# Altium schematic sheet Sch_Lora.SchDoc
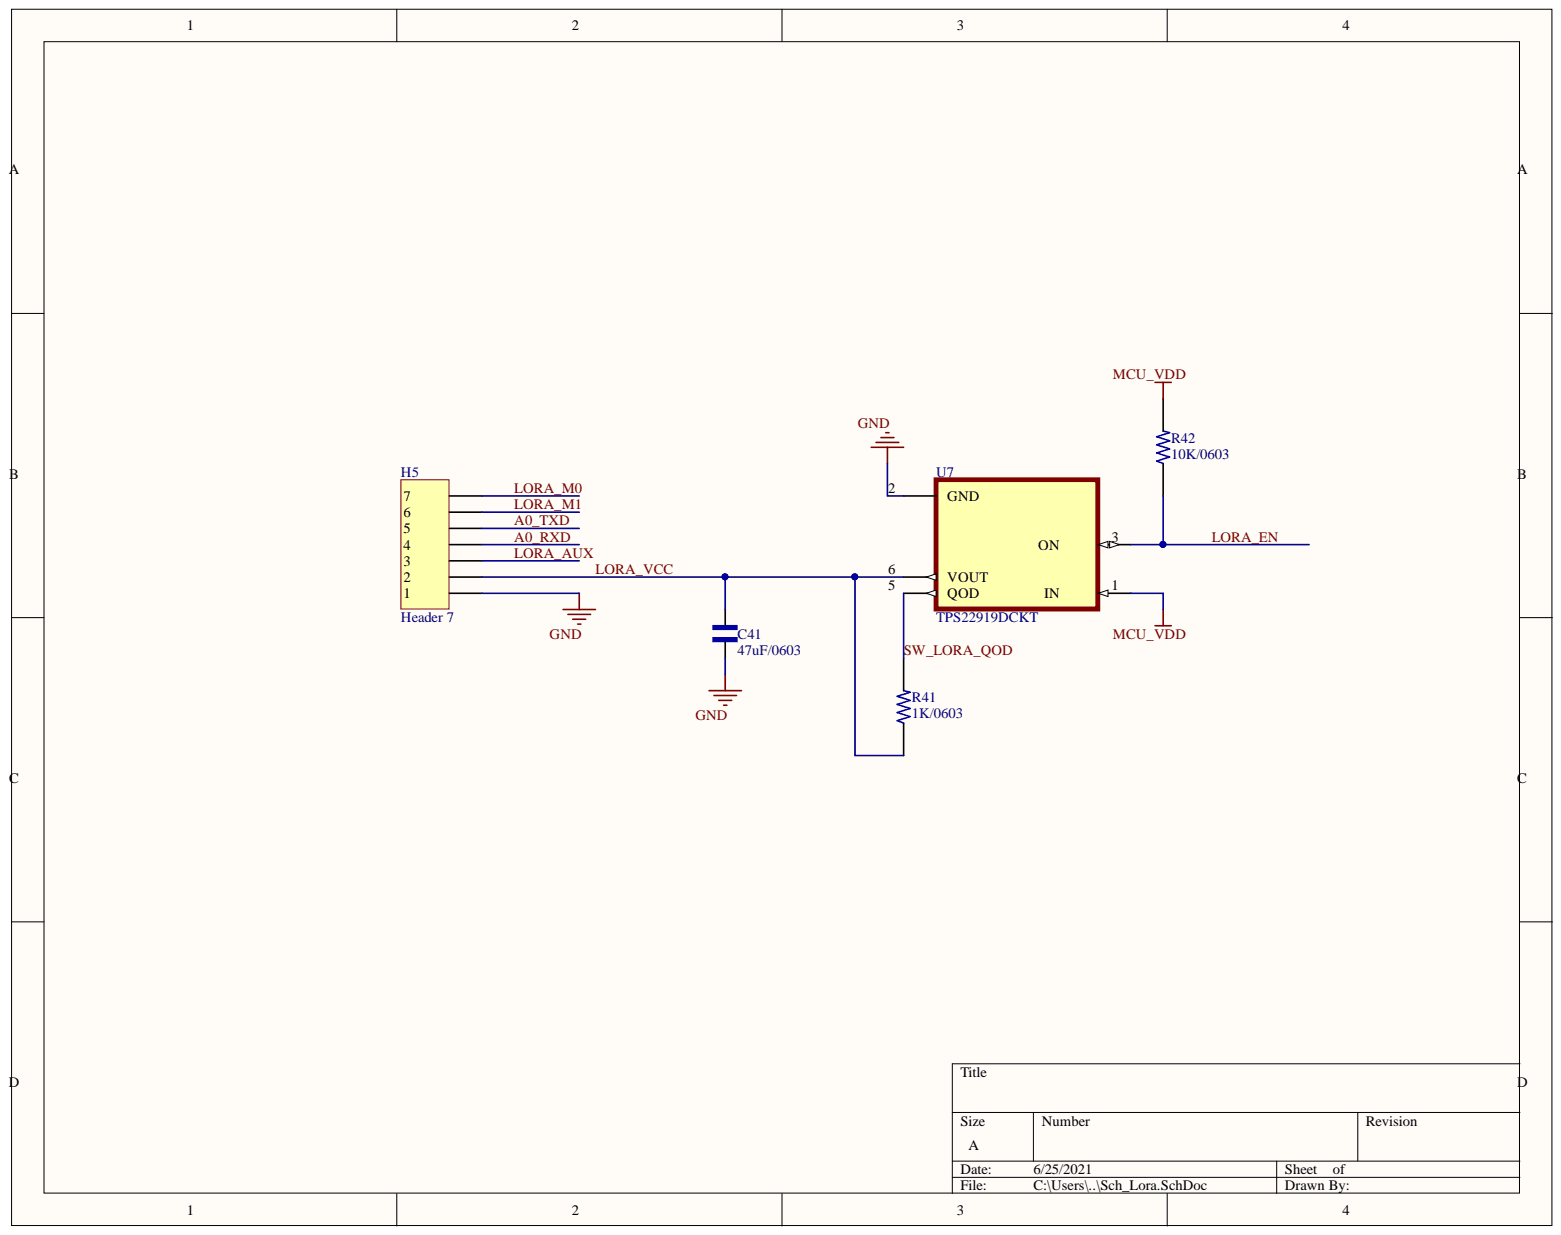
[diagram p0: Sch_Lora.SchDoc]

## Parts
C41  47uF/0603  [CAP-0603]
H5  Header 7  [HDR1X7]
R41  1K/0603  [RES-0603]
R42  10K/0603  [RES-0603]
U7  TPS22919DCKT  [SOT65]

## Nets
GND: C41.2 H5.1 U7.2(GND)
MCU_VDD: R42.2 U7.1
A0_RXD: H5.4
A0_TXD: H5.5
LORA_AUX: H5.3
LORA_EN: R42.1 U7.3
LORA_M0: H5.7
LORA_M1: H5.6
LORA_VCC: C41.1 H5.2 R41.1 U7.6(VOUT)
SW_LORA_QOD: R41.2 U7.5(QOD)

## Library data of the parts
C41 = Cap 0603: Ceramic Capacitor; MF: AVX; MP: LD031A330JAB2A_0; Availability: Unavailable; Component Kind: Standard; Package: 0603 AVX; Price: None; SnapEDA_Link: https://www.snapeda.com/parts/LD031A330JAB2A%5C500/AVX/view-part/2029942/?ref=snap; Library Name: tuandang.SchLib
H5 = Header 7: Header, 7-Pin; Component Kind: Standard; LatestRevisionDate: 17-Jul-2002; LatestRevisionNote: Re-released for DXP Platform.; Library Name: Miscellaneous Connectors.IntLib; Signal Integrity: Connector; Publisher: Altium Limited
R41 R42 = Resistor 0603: CRG0603 1% 4K75; MP: 1-1622960-0; Availability: Unavailable; Component Kind: Standard; Package: None; Price: None; Library Name: tuandang.SchLib
U7 = TPS22919DCKT: 5.5-V, 1.5-A, 90-m? load switch with adj. output discharge 6-SC70 -40 to 105; MF: Texas Instruments; Availability: Unavailable; Component Kind: Standard; DigiKey-Purchase-URL: https://snapeda.com/shop?store=DigiKey&id=3662569; Mouser-Purchase-URL: https://snapeda.com/shop?store=Mouser&id=3662569; MP: TPS22919DCKT; Package: SOT-SC70-6 Texas Instruments; Price: None; SnapEDA_Link: https://www.snapeda.com/parts/TPS22919DCKT/Texas%20Instruments/view-part/3662569/?ref=snap; Texas_Instruments-Purchase-URL: https://snapeda.com/shop?store=Texas+Instruments&id=3662569; Library Name: tuandang.SchLib
